# Altium schematic sheet RuggedBatteryPackV3-Controller.SchDoc
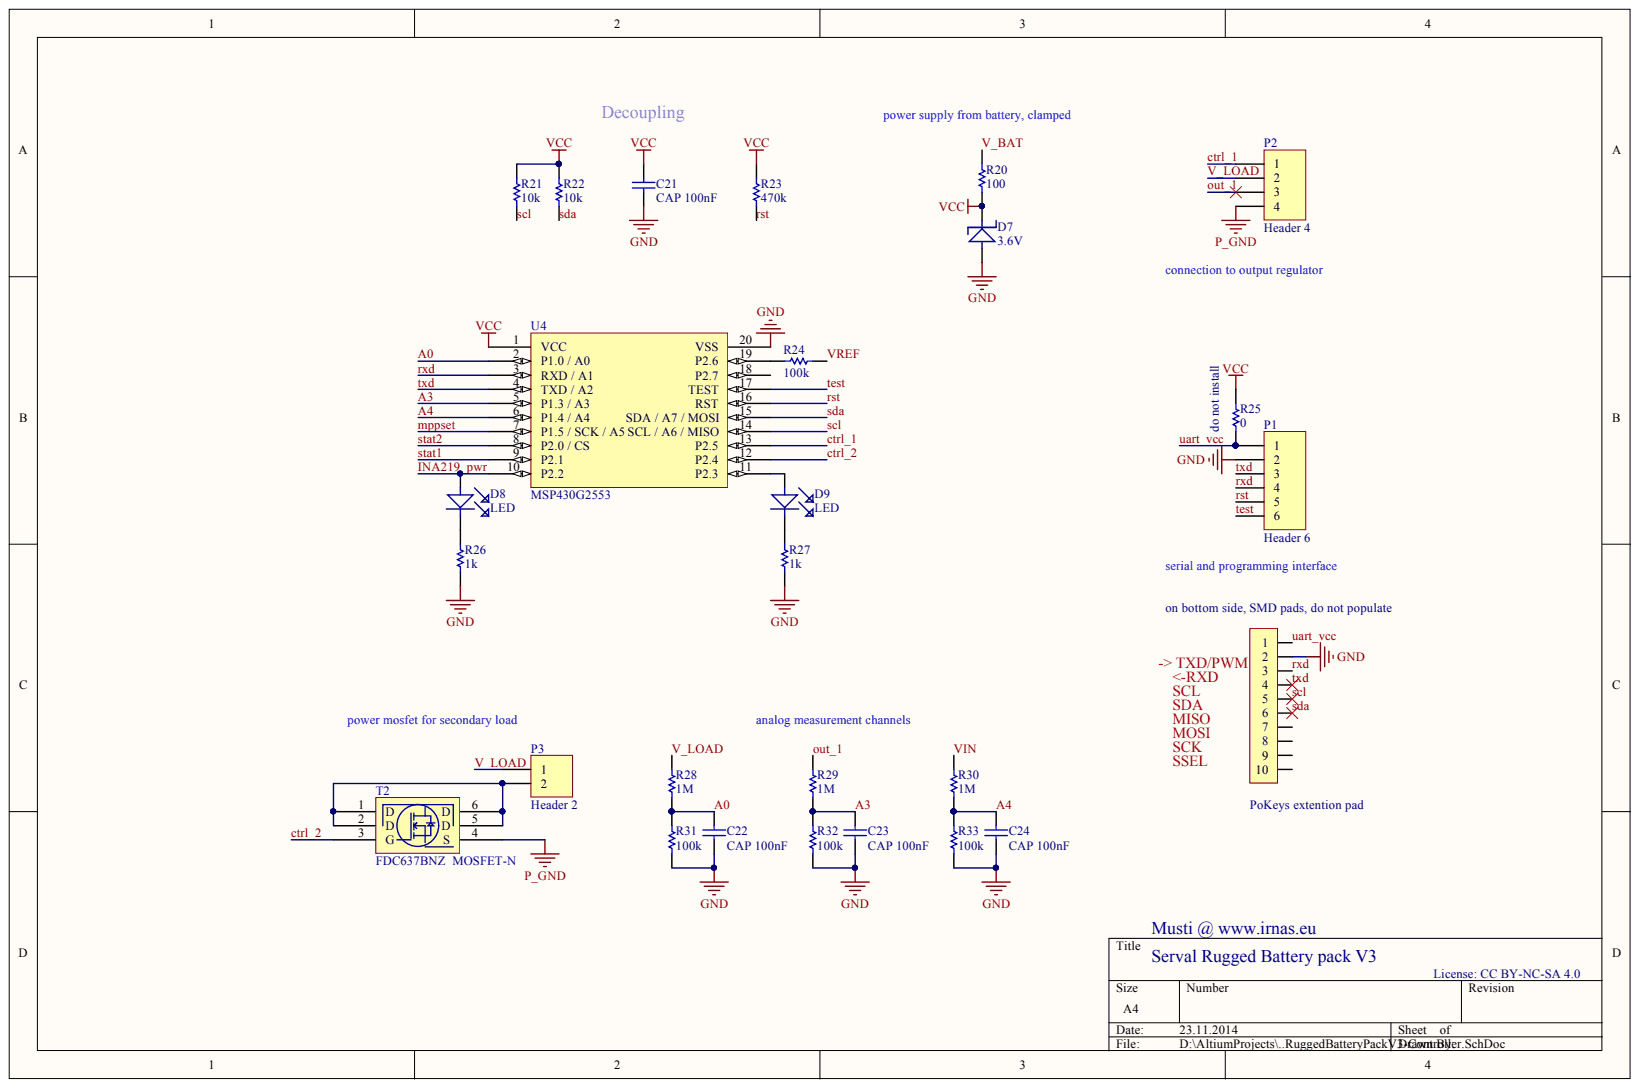
[diagram p0: RuggedBatteryPackV3-Controller.SchDoc]

## Parts
C21  CAP 100nF  value 100nF  [0603]
C22  CAP 100nF  value 100nF  [0603]
C23  CAP 100nF  value 100nF  [0603]
C24  CAP 100nF  value 100nF  [0603]
D7  3.6V  [DIOMELF3517N]
D8  LED  [0603]
D9  LED  [0603]
P1  Header 6  [HDR1X6]
P2  Header 4  [HDR1X4]
P3  Header 2  [HDR1X2]
PoExtensionIn1  PoKeys extention pad  [8-188275-0]
R20  100  [RESC0603(1608)_L]
R21  10k  [RESC0603(1608)_L]
R22  10k  [RESC0603(1608)_L]
R23  470k  [RESC0603(1608)_L]
R24  100k  [RESC0603(1608)_L]
R25  0  [RESC0603(1608)_L]
R26  1k  [RESC0603(1608)_L]
R27  1k  [RESC0603(1608)_L]
R28  1M  [RESC0603(1608)_L]
R29  1M  [RESC0603(1608)_L]
R30  1M  [RESC0603(1608)_L]
R31  100k  [RESC0603(1608)_L]
R32  100k  [RESC0603(1608)_L]
R33  100k  [RESC0603(1608)_L]
T2  FDC637BNZ  MOSFET-N  [SuperSOT-6]
U4  MSP430G2553  [PW020]

## Nets
GND: C21.1 C22.1 C23.1 C24.1 D7.2 P1.2 PoExtensionIn1.2 R26.1 R27.1 R31.1 R32.1 R33.1 U4.20(VSS)
P_GND: P2.4 T2.4(S)
VCC: C21.2 D7.1 R20.1 R21.2 R22.2 R23.2 R25.2 U4.1(VCC)
A0: C22.2 R28.1 R31.2 U4.2(P1.0_/_A0)
A3: C23.2 R29.1 R32.2 U4.5(P1.3_/_A3)
A4: C24.2 R30.1 R33.2 U4.6(P1.4_/_A4)
CTRL_1: P2.1 U4.13(P2.5)
CTRL_2: T2.3(G) U4.12(P2.4)
INA219_PWR: D8.1 U4.10(P2.2)
MPPSET: U4.7
OUT_1: P2.3 R29.2
RST: P1.5 R23.1 U4.16(RST)
RXD: P1.4 PoExtensionIn1.3 U4.3(RXD_/_A1)
SCL: PoExtensionIn1.5 R21.1 U4.14
SDA: PoExtensionIn1.6 R22.1 U4.15(SDA_/_A7_/_MOSI)
STAT1: U4.9(P2.1)
STAT2: U4.8(P2.0_/_CS)
TEST: P1.6 U4.17(TEST)
TXD: P1.3 PoExtensionIn1.4 U4.4(TXD_/_A2)
UART_VCC: P1.1 PoExtensionIn1.1 R25.1
V_BAT: R20.2
V_LOAD: P2.2 P3.1 R28.2
VIN: R30.2
VREF: R24.1
NetD8_2: D8.2 R26.2
NetD9_1: D9.1 U4.11(P2.3)
NetD9_2: D9.2 R27.2
NetP3_2: P3.2 T2.1(D) T2.2(D) T2.5(D) T2.6(D)
NetR24_2: R24.2 U4.19(P2.6)

Not connected: PoExtensionIn1 7 8 9 10; U4 18(P2.7)

## Library data of the parts
C21-C24 = CMP-1035-02584-1: CAP 100nF 50V ±10% 0603 (1608 Metric) Thickness 1mm SMD; Case-Metric: 1608; Rated Voltage: 16 V; Case-EIA: 0603; Component Kind: Standard; Max Thickness: 1 mm; Technology: SMT; Tolerance: ±10%
  C21 C23 C24: Library Name: Altium Content Vault
  C22: Library Name: General components
D7 = Zener: Zener 3.6V; Component Kind: Standard; Library Name: GeneralComponents.SchLib
D8 D9 = LED: LED diode; Component Kind: Standard; Library Name: GeneralComponents.SchLib
P1 = Header 6: Header, 6-Pin; Component Kind: Standard; LatestRevisionDate: 17-Jul-2002; LatestRevisionNote: Re-released for DXP Platform.; Library Name: Miscellaneous Connectors.IntLib; Signal Integrity: Connector; Publisher: Altium Limited
P2 = Header 4: Header, 4-Pin; Component Kind: Standard; LatestRevisionDate: 17-Jul-2002; LatestRevisionNote: Re-released for DXP Platform.; Library Name: Miscellaneous Connectors.IntLib; Signal Integrity: Connector; Publisher: Altium Limited
P3 = Header 2: Header, 2-Pin; Component Kind: Standard; LatestRevisionDate: 17-Jul-2002; LatestRevisionNote: Re-released for DXP Platform.; Library Name: Miscellaneous Connectors.IntLib; Signal Integrity: Connector; Publisher: Altium Limited
PoExtensionIn1 = 1-215079-0: Micro-Match female PCB connector 10 Positions THT; Component Kind: Standard; Library Name: BorisMicroMatch.SchLib
R20-R33 = CMP-1012-00026-1: 10R 0.1W 5% 0603 (1608 Metric)  SMD; Case-EIA: 0603; Case-Metric: 1608; Value: 10.00 Ohm; Component Kind: Standard; Library Name: Altium Content Vault; Power: 0.1 W; Technology: SMT; Tolerance: 5 %
T2 = FDC637BNZ  MOSFET-N: FDC637BNZ 5A; Component Kind: Standard; Library Name: GeneralComponents.SchLib
U4 = MSP430G2553: Component Kind: Standard; Description: MSP430G2553; Library Name: MSP430.SchLib
